# Altium schematic sheet LEDHeart.SchDoc
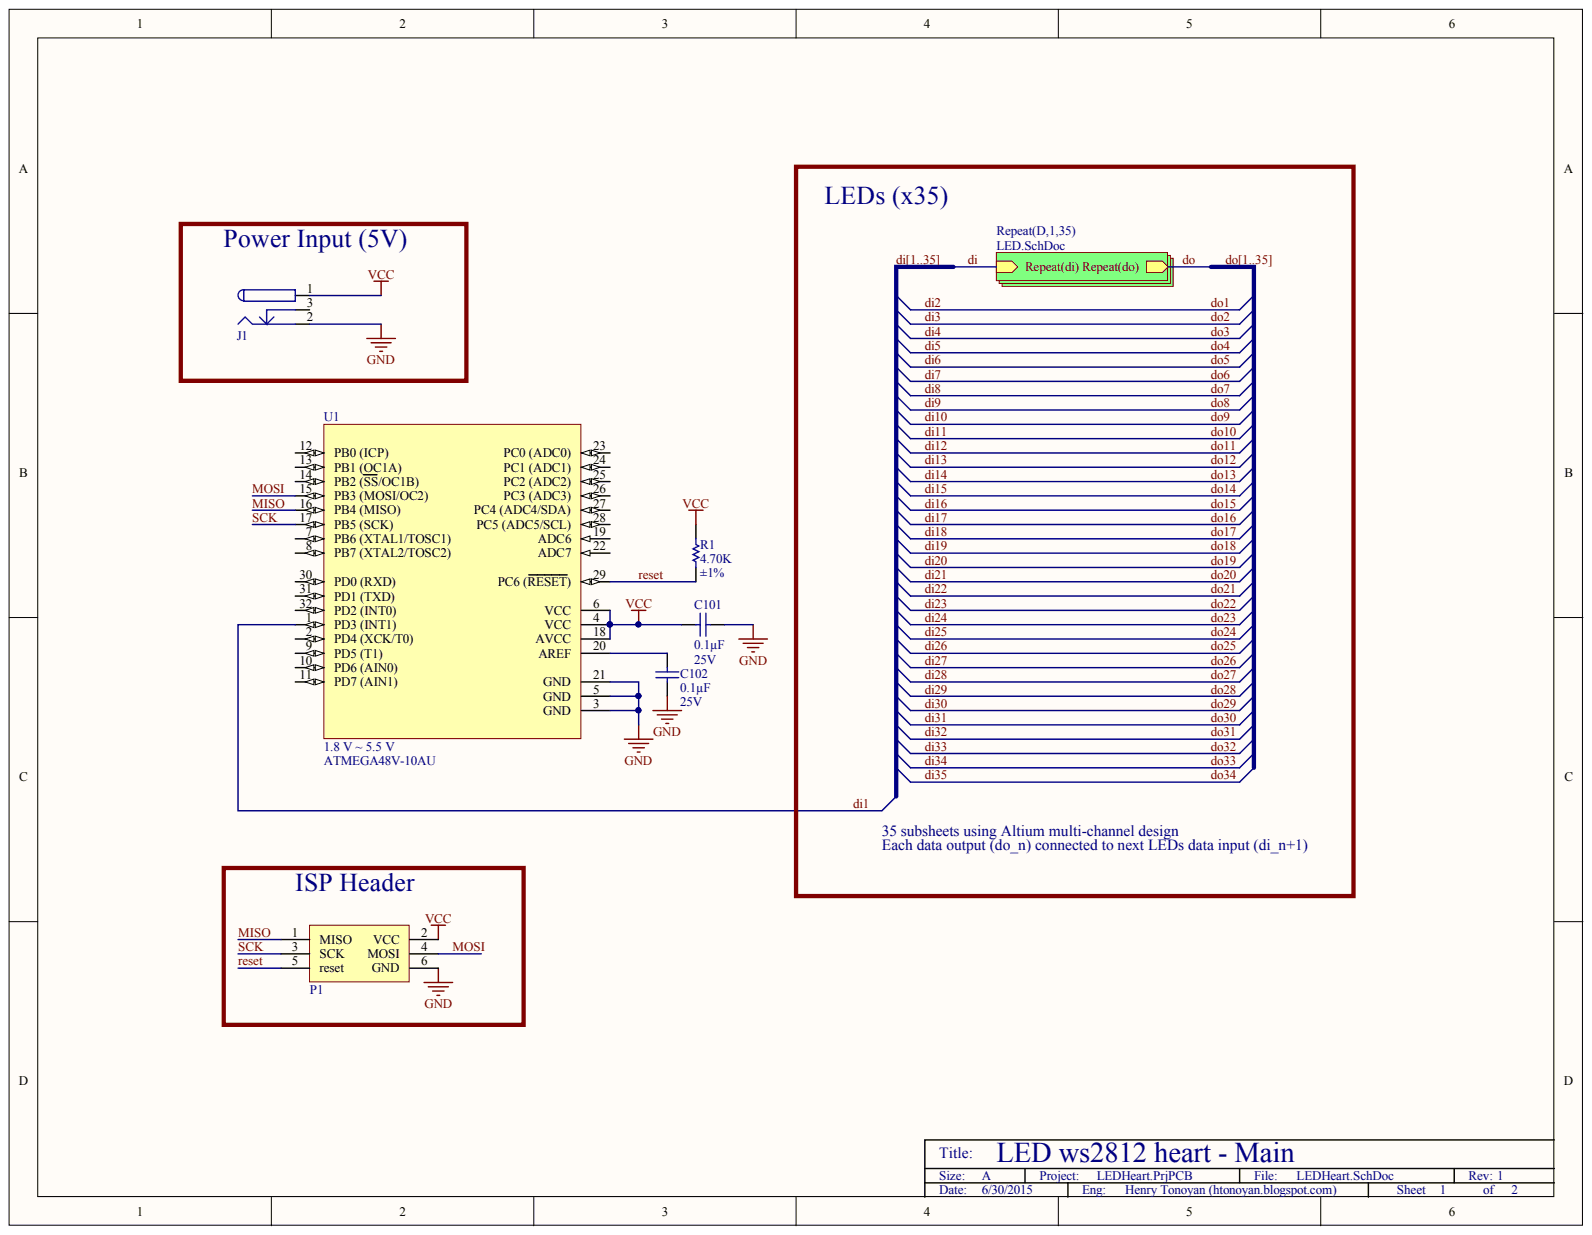
[diagram p0: LEDHeart.SchDoc]

## Parts
C101  Capacitance: 0.1µF  [0603]  (hidden comment: CAP CER .10UF 25V X7R 10% 0603)
C102  Capacitance: 0.1µF  Voltage - Rated: 25V  [0603]  (hidden comment: CAP CER .10UF 25V X7R 10% 0603)
J1  CONN_PWR2.0_SMT  [CONN_PWR2.0_SMT]  (value not drawn)
P1  Header, 3-Pin, Dual row  [CONN_HDR_SMT_6_2R]  (value not drawn)
R1  Resistance (Ohms): 4.70K  Tolerance: ±1%  [0603]  (hidden comment: RES_4.70K_OHM_1/10W_1%_0603_SMD)
U1  Manufacturer Part Number: ATMEGA48V-10AU  Voltage - Supply (Vcc/Vdd): 1.8 V ~ 5.5 V  [32A_N]  (hidden comment: IC_AVR_MCU_4K_10MHZ_1.8V_32TQFP)

## Nets
GND: C101.1 C102.1 J1.2 P1.6(GND) U1.3(GND) U1.5(GND) U1.21(GND)
VCC: C101.2 J1.1 P1.2(VCC) R1.2 U1.4(VCC) U1.6(VCC) U1.18(AVCC)
DI1: U1.1(PD3_(INT1))  [also labelled DI]
MISO: P1.1(MISO) U1.16(PB4_(MISO))
MOSI: P1.4(MOSI) U1.15(PB3_(MOSI/OC2))
RESET: P1.5(reset) R1.1 U1.29(PC6_(RESET))
SCK: P1.3(SCK) U1.17(PB5_(SCK))
NetC102_2: C102.2 U1.20(AREF)
NetJ1_3: J1.3
NetU1_2: U1.2(PD4_(XCK/T0))
NetU1_7: U1.7(PB6_(XTAL1/TOSC1))
NetU1_8: U1.8(PB7_(XTAL2/TOSC2))
NetU1_9: U1.9(PD5_(T1))
NetU1_10: U1.10(PD6_(AIN0))
NetU1_11: U1.11(PD7_(AIN1))
NetU1_12: U1.12(PB0_(ICP))
NetU1_13: U1.13(PB1_(OC1A))
NetU1_14: U1.14(PB2_(SS/OC1B))
NetU1_19: U1.19(ADC6)
NetU1_22: U1.22(ADC7)
NetU1_23: U1.23(PC0_(ADC0))
NetU1_24: U1.24(PC1_(ADC1))
NetU1_25: U1.25(PC2_(ADC2))
NetU1_26: U1.26(PC3_(ADC3))
NetU1_27: U1.27(PC4_(ADC4/SDA))
NetU1_28: U1.28(PC5_(ADC5/SCL))
NetU1_30: U1.30(PD0_(RXD))
NetU1_31: U1.31(PD1_(TXD))
NetU1_32: U1.32(PD2_(INT0))
DI2:  [also labelled DI]
DI3:  [also labelled DI]
DI4:  [also labelled DI]
DI5:  [also labelled DI]
DI6:  [also labelled DI]
DI7:  [also labelled DI]
DI8:  [also labelled DI]
DI9:  [also labelled DI]
DI10:  [also labelled DI]
DI11:  [also labelled DI]
DI12:  [also labelled DI]
DI13:  [also labelled DI]
DI14:  [also labelled DI]
DI15:  [also labelled DI]
DI16:  [also labelled DI]
DI17:  [also labelled DI]
DI18:  [also labelled DI]
DI19:  [also labelled DI]
DI20:  [also labelled DI]
DI21:  [also labelled DI]
DI22:  [also labelled DI]
DI23:  [also labelled DI]
DI24:  [also labelled DI]
DI25:  [also labelled DI]
DI26:  [also labelled DI]
DI27:  [also labelled DI]
DI28:  [also labelled DI]
DI29:  [also labelled DI]
DI30:  [also labelled DI]
DI31:  [also labelled DI]
DI32:  [also labelled DI]
DI33:  [also labelled DI]
DI34:  [also labelled DI]
DI35:  [also labelled DI]
DO35:  [also labelled DO]

## Library data of the parts
C101 C102 = CAP_CER_.10UF_25V_X7R_10%_0603_1: Manufacturer: TDK Corporation; Manufacturer Part Number: C1608X7R1E104K; Applications: General Purpose; Category: Capacitors; Component Kind: Standard; ComponentLink1Description: Datasheet; ComponentLink1URL: http://www.tdk.com/pdf/general_B11.pdf; Description: CAP CER .10UF 25V X7R 10% 0603; Features: -; Height - Seated (Max): -; Lead Spacing: -; Lead Style: -; Library Name: parts.SchLib; Mounting Type: Surface Mount, MLCC; Operating Temperature: -55°C ~ 125°C; Package / Case: 0603 (1608 Metric); Packaging: Cut Tape (CT); Pricing 1: 1=0.1, 10=0.024, 100=0.011, 250=0.009, 500=0.0077, 1000=0.006 (USD); Ratings: -; RoHS: RoHS Compliant; Size / Dimension: 0.063" L x 0.031" W (1.60mm x 0.80mm); Stock 1: 1271235; Supplier 1: Digi-Key; Supplier Part Number 1: 445-1316-1-ND; Temperature Coefficient: X7R; Thickness (Max): 0.037" (0.95mm); Tolerance: ±10%
  C101: Voltage - Rated: 25V
J1 = CONN_PWR2.0_SMT: CONN PWR JACK 2.0X6.3MM SMT; Manufacturer: CUI Inc; Manufacturer Part Number: PJ-036A-SMT; Current Rating: 2.5A; Gender: Male; Internal Switch(s): Single Switch, Normally Closed; Number of Positions/Contacts: 2 Conductors, 3 Contacts; Plug/Mating Plug Diameter: 2.1mm ID, 5.5mm OD; Shielding: Unshielded; Voltage - Rated: 16VDC; Category: Connectors, Interconnects; Color: Black; Component Kind: Standard; ComponentLink1Description: Datasheet; ComponentLink1URL: http://www.cui.com/Product/Resource/DigiKeyPDF/PJ-036A-SMT.pdf; Connector Type: Jack; Contact Material: Brass; Contact Material - Plating: Silver; Features: -; Housing Color: Black; Housing Material: Thermoplastic; Library Name: parts.SchLib; Mounting Type: Surface Mount, Right Angle; Operating Temperature: -25°C ~ 85°C; Pricing 1: 1=1.67, 10=1.297, 100=0.9895 (USD); RoHS: RoHS Compliant; Stock 1: 20300; Supplier 1: Digi-Key; Supplier Part Number 1: CP-036APJCT-ND; Termination: Solder
P1 = CONN_Header_0.100_6pos: Manufacturer: Molex Connector Corporation; Connector Type: Header, Unshrouded, Breakaway; Number of Positions: 6; Number of Positions Loaded: All; Pitch: 0.100" (2.54mm); Category: Connectors, Interconnects; Color: Black; Component Kind: Standard; ComponentLink1Description: Datasheet; ComponentLink1URL: http://www.molex.com/pdm_docs/sd/015912060_sd.pdf; Contact Finish: Tin; Contact Finish Thickness: 150µin (3.81µm); Contact Mating Length: 0.240" (6.10mm); Contact Type: Male Pin; Description: Header, 3-Pin, Dual row; Fastening Type: -; Features: -; Library Name: parts.SchLib; Manufacturer Part Number: 15-91-2060; Mounting Type: Surface Mount; Number of Rows: 2; Packaging: Bulk; Pricing 1: 1=0.82, 10=0.576, 50=0.4442, 100=0.3866, 250=0.329, 500=0.31256, 1000=0.27965, 2500=0.22208, 5000=0.21879 (USD); RoHS: RoHS Compliant; Row Spacing: 0.100" (2.54mm); Stock 1: 2541; Supplier 1: Digi-Key; Supplier Part Number 1: WM17449-ND; Termination: Solder
R1 = RES_4.70K_OHM_1/10W_1%_0603_SMD: RES 4.70K OHM 1/10W 1% 0603 SMD; Manufacturer: Panasonic Electronic Components; Manufacturer Part Number: ERJ-3EKF4701V; Power (Watts): 0.1W, 1/10W; Temperature Coefficient: ±100ppm/°C; Category: Resistors; Component Kind: Standard; ComponentLink1Description: Datasheet; ComponentLink1URL: http://industrial.panasonic.com/www-cgi/jvcr13pz.cgi?E+PZ+3+AOA0002+ERJ3EKF4701V+7+WW; Composition: Thick Film; Features: -; Height: 0.022" (0.55mm); Library Name: parts.SchLib; Number of Terminations: 2; Package / Case: 0603 (1608 Metric); Packaging: Cut Tape (CT); Pricing 1: 1=0.1, 50=0.0138, 100=0.0105, 250=0.0078, 500=0.00576, 1000=0.00384 (USD); RoHS: RoHS Compliant; Size / Dimension: 0.063" L x 0.031" W (1.60mm x 0.80mm); Stock 1: 955812; Supplier 1: Digi-Key; Supplier Part Number 1: P4.70KHCT-ND
U1 = IC_AVR_MCU_4K_10MHZ_1.8V_32TQFP_1: IC AVR MCU 4K 10MHZ 1.8V 32TQFP; Manufacturer: Atmel; Connectivity: I²C, SPI, UART/USART; Core Size: 8-Bit; Data Converters: A/D 8x10b; EEPROM Size: 256 x 8; Number of I /O: 23; Oscillator Type: Internal; Peripherals: Brown-out Detect/Reset, POR, PWM, WDT; Program Memory Size: 4KB (2K x 16); Program Memory Type: FLASH; RAM Size: 512 x 8; Category: Integrated Circuits (ICs); Component Kind: Standard; ComponentLink1Description: Datasheet; ComponentLink1URL: http://www.atmel.com/Images/2545s.pdf; Core Processor: AVR; Library Name: parts.SchLib; Operating Temperature: -40°C ~ 85°C; Package / Case: 32-TQFP; Packaging: Tray; Pricing 1: 1=2.73, 25=1.7144, 100=1.524 (USD); RoHS: RoHS Compliant; Speed: 10MHz; Stock 1: 15971; Supplier 1: Digi-Key; Supplier Part Number 1: ATMEGA48V-10AU-ND
